# Altium schematic sheet Temp Sensor Interface.SchDoc("Temp Sensor Interface")
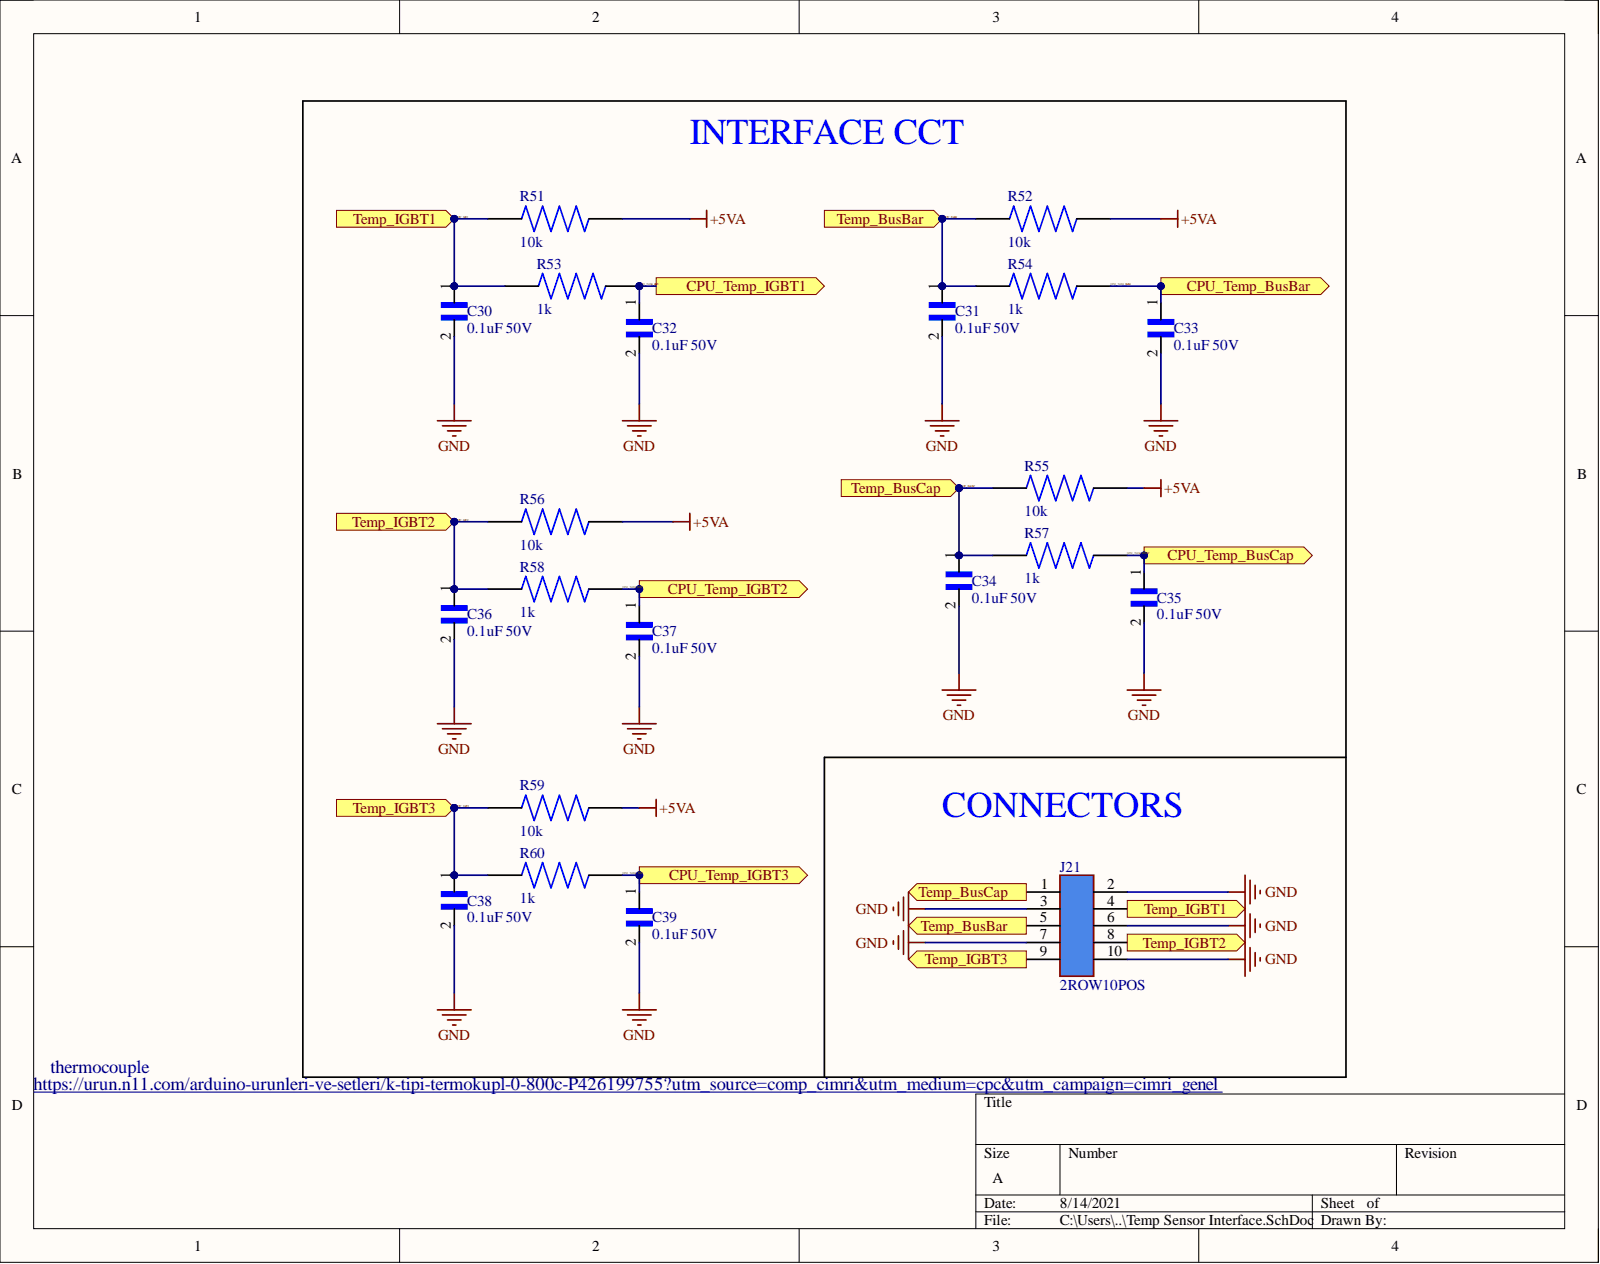
[diagram p0: Temp Sensor Interface.SchDoc]

## Parts
C30  0.1uF 50V  [CAP 0805(2012 metric)]
C31  0.1uF 50V  [CAP 0805(2012 metric)]
C32  0.1uF 50V  [CAP 0805(2012 metric)]
C33  0.1uF 50V  [CAP 0805(2012 metric)]
C34  0.1uF 50V  [CAP 0805(2012 metric)]
C35  0.1uF 50V  [CAP 0805(2012 metric)]
C36  0.1uF 50V  [CAP 0805(2012 metric)]
C37  0.1uF 50V  [CAP 0805(2012 metric)]
C38  0.1uF 50V  [CAP 0805(2012 metric)]
C39  0.1uF 50V  [CAP 0805(2012 metric)]
J21  2ROW10POS  [2ROW10POS]
R51  10k  [RES 0805(2012 metric)]
R52  10k  [RES 0805(2012 metric)]
R53  1k  [RES 0805(2012 metric)]
R54  1k  [RES 0805(2012 metric)]
R55  10k  [RES 0805(2012 metric)]
R56  10k  [RES 0805(2012 metric)]
R57  1k  [RES 0805(2012 metric)]
R58  1k  [RES 0805(2012 metric)]
R59  10k  [RES 0805(2012 metric)]
R60  1k  [RES 0805(2012 metric)]

## Ports
CPU_Temp_BusBar, CPU_Temp_BusCap, CPU_Temp_IGBT1, CPU_Temp_IGBT2, CPU_Temp_IGBT3, Temp_BusBar, Temp_BusCap, Temp_IGBT1, Temp_IGBT2, Temp_IGBT3

## Nets
+5VA: R51.2 R52.2 R55.2 R56.2 R59.2
GND: C30.2 C31.2 C32.2 C33.2 C34.2 C35.2 C36.2 C37.2 C38.2 C39.2 J21.2 J21.3 J21.6 J21.7 J21.10
CPU_Temp_BusBar: C33.1 R54.2 ; port CPU_Temp_BusBar
CPU_Temp_BusCAP: C35.1 R57.2 ; port CPU_Temp_BusCap
CPU_Temp_IGBT1: C32.1 R53.2 ; port CPU_Temp_IGBT1
CPU_Temp_IGBT2: C37.1 R58.2 ; port CPU_Temp_IGBT2
CPU_Temp_IGBT3: C39.1 R60.2 ; port CPU_Temp_IGBT3
TEMP_BusBar: C31.1 J21.5 R52.1 R54.1 ; port Temp_BusBar
TEMP_BusCAP: C34.1 J21.1 R55.1 R57.1 ; port Temp_BusCap
TEMP_IGBT1: C30.1 J21.4 R51.1 R53.1 ; port Temp_IGBT1
TEMP_IGBT2: C36.1 J21.8 R56.1 R58.1 ; port Temp_IGBT2
TEMP_IGBT3: C38.1 J21.9 R59.1 R60.1 ; port Temp_IGBT3

## Library data of the parts
C30-C39 = 0.1uF 50V: 0.1uF 50VDC 0805; Component Kind: Standard; Library Name: 0.1uF 50VDC 0805.SchLib
R51 R52 R55 R56 R59 = 10k 0.1W 0805: Component Kind: Standard; Description: 10k 0.1W 0805; Library Name: 10k 0.1W 0805.SchLib
R53 R54 R57 R58 R60 = 1k: Resistor 0805 1k ohm 0.1W; Component Kind: Standard; Library Name: 1k 0805 0.1W.SchLib
J21 = 2ROW10POS: Component Kind: Standard; Library Name: 2ROW10POS.SchLib
